# Altium schematic sheet AccelPCB.SchDoc
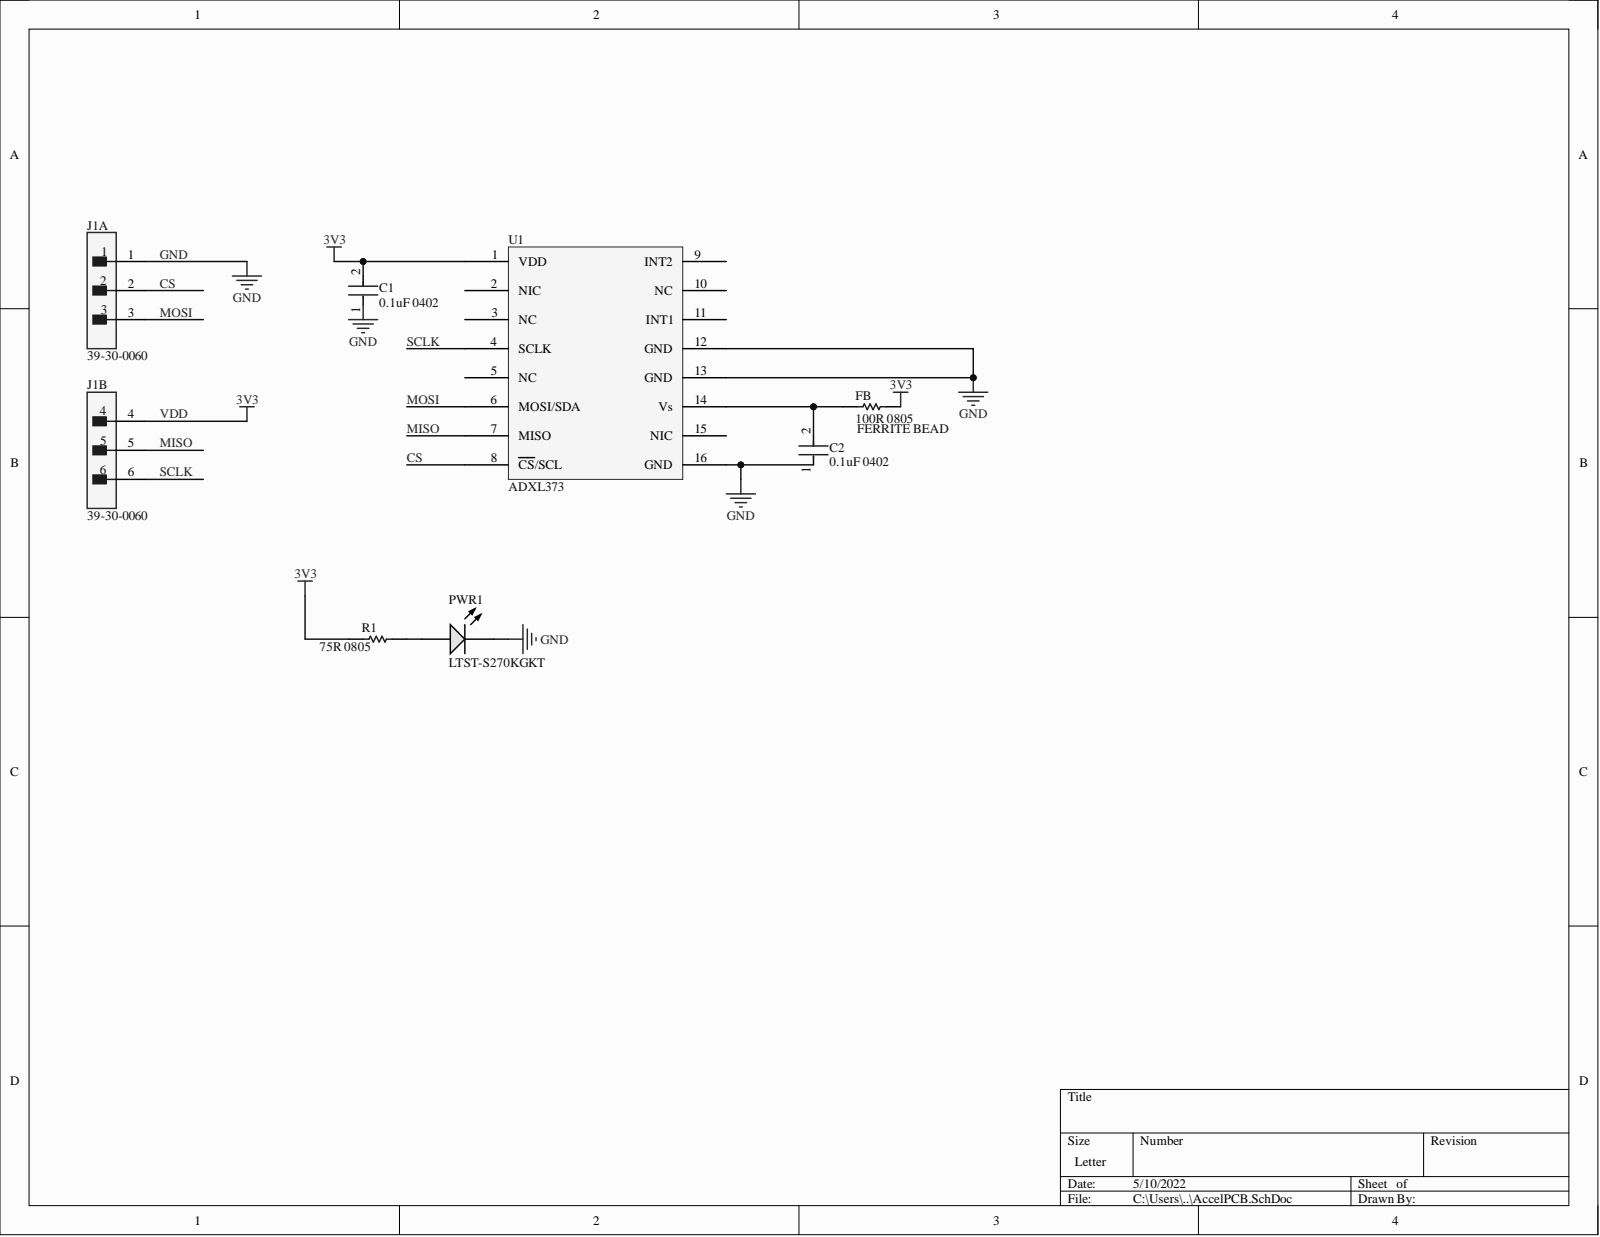
[diagram p0: AccelPCB.SchDoc]

## Parts
C1  0.1uF 0402  [FP-GRM15-MFG]
C2  0.1uF 0402  [FP-GRM15-MFG]
FB  100R 0805  [RESC2013X50X30LL20T20]
J1  39-30-0060  [FP-39-30-0060-MFG]  (parts A,B)
PWR1  LTST-S270KGKT  [AVAG-HSMX-C190_V]
R1  75R 0805  [RESC2013X50X30LL20T20]
U1  ADXL373  [FP-CC-16-3-MFG]

## Nets
CS: J1.2 U1.8(CS/SCL)
GND: C1.1 C2.1 J1.1 PWR1.2 U1.12(GND) U1.13(GND) U1.16(GND)
MISO: J1.5 U1.7(MISO)
MOSI: J1.3 U1.6(MOSI/SDA)
SCLK: J1.6 U1.4(SCLK)
VDD: C1.2 FB.2 J1.4 R1.1 U1.1(VDD)
NetC2_2: C2.2 FB.1 U1.14(Vs)
NetPWR1_1: PWR1.1 R1.2

Not connected: U1 2(NIC) 3(NC) 5(NC) 9(INT2) 10(NC) 11(INT1) 15(NIC)

## Library data of the parts
C1 C2 = CMP-2100-03641-2: CAP CER 0.1UF 16V X7R 0402; Manufacturer: Murata; Manufacturer Part Number: GRM155R71C104KA88D; Capacitance: 0.10μF; Material: Ceramic; Standoff Height: 0mm; Tolerance: 10%; Value: 0.10μF; Voltage Rating: 16Vdc; Category: Cap; Component Kind: Standard; ComponentLink2Description: Datasheet; ComponentLink2URL: https://search.murata.co.jp/Ceramy/image/img/A01X/G101/ENG/GRM155R71H471KA01-01A.pdf; Device Class L1: Passive Components; Device Class L2: Capacitors; Device Class L3: Ceramic Capacitors; Digikey Description: CAP CER 0.1UF 16V X7R 0402; Height: 0.55mm; Ipc Land Pattern Name: CAPC10050X219; Lead Free: yes; Library Name: Altium Content Vault; Mouser Description: 0402 0.1 uF 16V ±10 % Tolerance X7R SMT Multilayer Ceramic Capacitor; Octopart: https://octopart.com/grm155r71c104ka88d-murata-196880; Package: GRM15; RoHS: TRUE; Temperature: -55°C to +125°C; Temperature Characteristic: X7R; Temperature Range High: +125°C; Temperature Range Low: -55°C
FB R1 = CMP-2001-04896-1: Manufacturer: Vishay Dale; Part Number: CRCW08050000Z0EA; Component Kind: Standard; Library Name: Altium Content Vault
J1 = CMP-04776-000273-1: CONN HEADER R/A 6POS 4.2MM; Manufacturer Series: 5569; Contact Resistance: 10mR; Current Rating: 13A; DIN Conformance: No; Gender: Male; Glow Wire Compliant: Non-compliant; Insulation Resistance: 1GR; Mating Information: MULTIPLE MATING PARTS AVAILABLE; Max Voltage Rating (AC): 600V; Number of Circuits: 6; Number of Ports: 1; Option: General Purpose; Row Spacing: 0.165inch; Component Kind: Standard; Contact Finish - Mating: TIN (200) OVER COPPER; Contact Finish - Termination: TIN (200) OVER COPPER; Contact Gender: Male; Contact Material: Brass; Contact Plating: Tin; Contact Type: Male Pin; Depth: 19.4mm; ELV: Compliant; Empty Shell: No; Fastening Type: Ramp; Filter Feature: No; Flammability Rating: UL94 V-0; Halogen Free: Low Halogen; Housing Color: Natural; Housing Material: Polyamide; IEC Conformance: No; Lead Free: Lead Free; Library Name: Altium Content Vault; Material: Nylon; Max Operating Temperature: 105°C; MIL Conformance: No; Min Operating Temperature: -40°C; Mixed Contacts: No; Mount: Through Hole; Number of Contacts: 6; Number of Positions: 6; Number of Rows: 2; Orientation: Right Angle; Packaging: Bag; Pitch: 4.2mm; Radiation Hardening: No; Series: Mini-Fit® Jr™ 5569; Shell Material: POLYAMIDE66; Termination: Solder; Voltage Rating: 600V; Voltage Rating (DC): 600V
PWR1 = CMP-1744-00003-1: LED Uni-Color Yellow, 65 mW, 20 mA, -40 to 85 degC, 2-Pin SMD, RoHS, Tape and Reel; Case\Package: 0603; Power Rating: 100 mW; Resistance: 390 Ohm; Tolerance: 1%; Voltage Rating: 50 V; Case Code (Imperial): 0603; Case Code (Metric): 1608; Component Kind: Standard; ComponentLink1Description: Manufacturer URL; ComponentLink1URL: http://www.avagotech.com/; ComponentLink2Description: Datasheet; ComponentLink2URL: http://docs.avagotech.com/docs/AV02-0551EN; ComponentLink3Description: Package Specification; ComponentLink3URL: http://www.fairchildsemi.com/dwg/MA/MA03D.pdf; DatasheetVersion: Mar-12; Height: 0.45 mm; Length: 1.6 mm; Library Name: Altium Content Vault; Max Operating Temperature: 155 degC; Min Operating Temperature: -55 degC; Mount: Surface Mount; Package Quantity: 5000; PackageDescription: 2-Pin Surface Mount Device, Body 1.6 x 0.8 mm, Height 0.9 mm; PackageReference: HSMX-C190; Packaging: Tape and Reel; Pins: 2; REACH SVHC: No SVHC; RoHSCompliant: true; Voltage Rating (DC): 50 V; Width: 0.8 mm
U1 = Component_1: Component Kind: Standard; Library Name: ADXL373.SchLib
